# Altium schematic sheet 04_POWER_Switch.SchDoc(04_POWER_Switch)
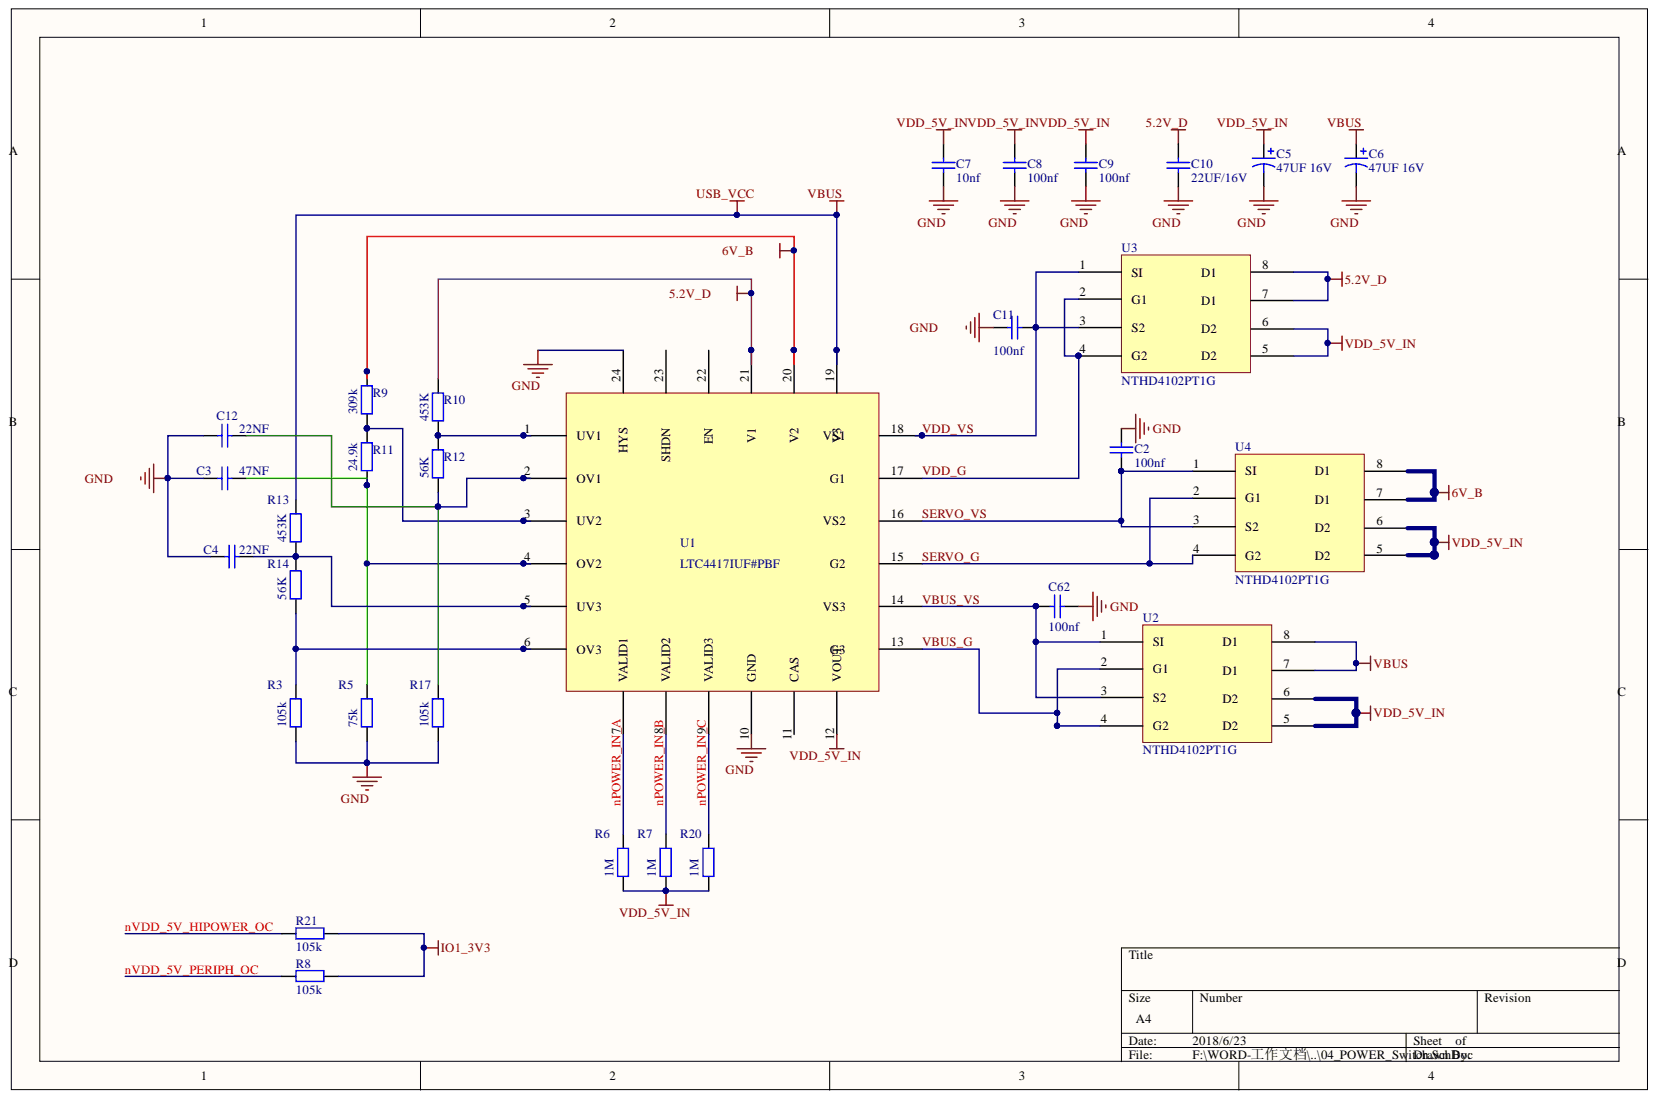
[diagram p0: 04_POWER_Switch.SchDoc]

## Parts
C2  100nf  [0402CAP]
C3  47NF  [0402CAP]
C4  22NF  [0402CAP]
C5  47UF 16V  [7343CAP]
C6  47UF 16V  [7343CAP]
C7  10nf  [0402CAP]
C8  100nf  [0402CAP]
C9  100nf  [0402CAP]
C10  22UF/16V  [0805CAP]
C11  100nf  [0402CAP]
C12  22NF  [0402CAP]
C62  100nf  [0402CAP]
R3  105k  [R0402]
R5  75k  [R0402]
R6  1M  [R0402]
R7  1M  [R0402]
R8  105k  [R0402]
R9  309k  [R0402]
R10  453K  [R0402]
R11  24.9k  [R0402]
R12  56K  [R0402]
R13  453K  [R0402]
R14  56K  [R0402]
R17  105k  [R0402]
R20  1M  [R0402]
R21  105k  [R0402]
U1  LTC4417IUF#PBF  [QFN24]
U2  NTHD4102PT1G  [1206-8]
U3  NTHD4102PT1G  [1206-8]
U4  NTHD4102PT1G  [1206-8]

## Nets
5.2V_D: C10.2 R10.2 U1.21 U3.7 U3.8
6V_B: R9.2 U1.20 U4.7 U4.8
GND: C2.2 C3.2 C4.2 C5.C C6.C C7.1 C8.1 C9.1 C10.1 C11.2 C12.2 C62.2 R3.1 R5.1 R17.1 U1.10(GND) U1.24
IO1_3V3: R8.2 R21.2
USB_VCC: C6.A R13.2 U1.19 U2.7 U2.8
VDD_5V_IN: C5.A C7.2 C8.2 C9.2 R6.1 R7.1 R20.1 U1.12(VOUT) U2.5 U2.6 U3.5 U3.6 U4.5 U4.6
NPOWER_IN_A: R6.2 U1.7(VALID1)
NPOWER_IN_B: R7.2 U1.8(VALID2)
NPOWER_IN_C: R20.2 U1.9(VALID3)
NVDD_5V_HIPOWER_OC: R21.1
NVDD_5V_PERIPH_OC: R8.1
SERVO_G: U1.15 U4.2(G1) U4.4(G2)
SERVO_VS: C2.1 U1.16 U4.1(SI) U4.3(S2)
VBUS_G: U1.13 U2.2(G1) U2.4(G2)
VBUS_VS: C62.1 U1.14 U2.1(SI) U2.3(S2)
VDD_G: U1.17 U3.2(G1) U3.4(G2)
VDD_VS: C11.1 U1.18 U3.1(SI) U3.3(S2)
NetC3_1: C3.1 R5.2 R11.1 U1.4(OV2)
NetC4_1: C4.1 R13.1 R14.2 U1.5(UV3)
NetC12_1: C12.1 R12.1 R17.2 U1.2(OV1)
NetR3_2: R3.2 R14.1 U1.6(OV3)
NetR9_1: R9.1 R11.2 U1.3(UV2)
NetR10_1: R10.1 R12.2 U1.1(UV1)

Not connected: U1 11(CAS) 22 23

## Library data of the parts
C2-C4 C7-C12 C62 = Cap: Value: 100pF; Component Kind: Standard; LatestRevisionDate: 17-Jul-2002; LatestRevisionNote: Re-released for DXP Platform.; Library Name: Miscellaneous Devices.IntLib; PackageDescription: Radial Cap, Thru-Hole; 2 Leads; 0.3 in Pin Spacing; PackageReference: RAD-0.3; Published: 8-Jun-2000; Signal Integrity: Cap; Simulation: CAP; Publisher: Altium Limited
C5 C6 = Cap Pol1: Polarized Capacitor (Radial); Value: 100pF; Component Kind: Standard; LatestRevisionDate: 17-Jul-2002; LatestRevisionNote: Re-released for DXP Platform.; Library Name: Miscellaneous Devices.IntLib; PackageDescription: Polarized Capacitor (Radial); 2 Leads; PackageReference: RB7.6-15; Published: 8-Jun-2000; Signal Integrity: Cap Pol; Simulation: CAP; Publisher: Altium Limited
R3 R5-R14 R17 R20 R21 = Res2: Resistor; Value: 1K; Component Kind: Standard; LatestRevisionDate: 17-Jul-2002; LatestRevisionNote: Re-released for DXP Platform.; Library Name: Miscellaneous Devices.IntLib; PackageDescription: Axial Device, Thru-Hole; 2 Leads; 0.4 in Pin Spacing; PackageReference: AXIAL-0.4; Published: 8-Jun-2000; Signal Integrity: Res; Simulation: RESISTOR; Publisher: Altium Limited
U1 = LTC4417IUF#PBF: Component Kind: Standard; Library Name: hack.SchLib
U2-U4 = NTHD4102PT1G: Component Kind: Standard; Library Name: hack.SchLib
